# Altium schematic sheet PAGE2-POWER SUPPLY.SchDoc
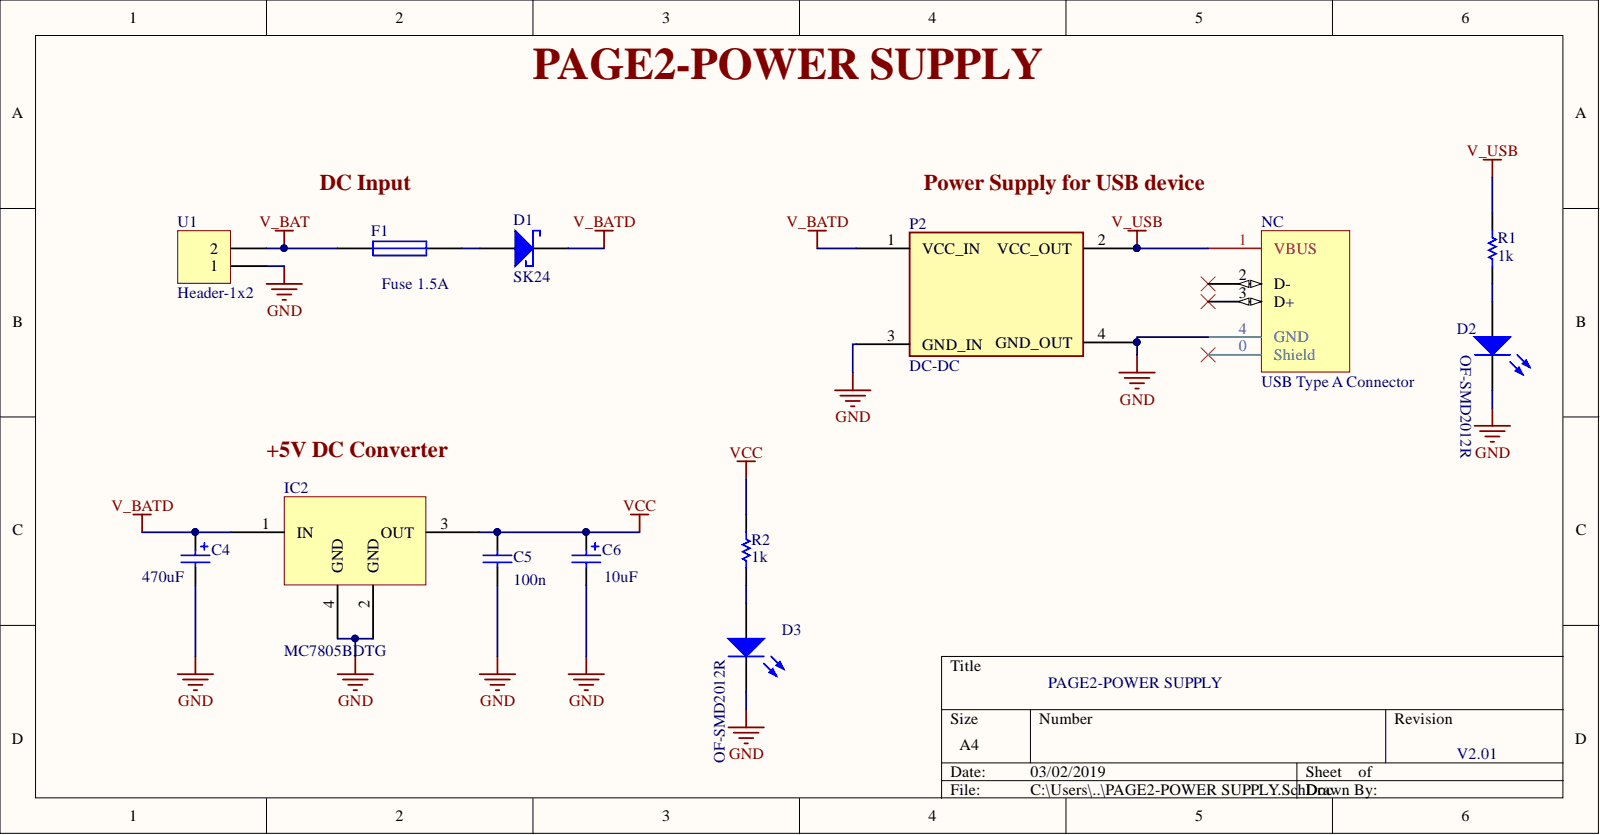
[diagram p0: PAGE2-POWER SUPPLY.SchDoc]

## Parts
C4  470uF  [Cap SMD 8x10]
C5  100n  [OF-SMD2012R]
C6  10uF  [Cap SMD 4x5.3]
D1  SK24  [DSO-C2/X3.3]
D2  OF-SMD2012R  [OF-SMD2012R]
D3  OF-SMD2012R  [OF-SMD2012R]
F1  Fuse 1.5A  [Fuse SMD 1812]
IC2  MC7805BDTG  [DPAK]
P2  DC-DC  [DC-DC LM2579 module]
R1  1k  [Res SMD 0805]
R2  1k  [Res SMD 0805]
U1  Implementation: Header-1x2  [CTB1000/2]  (hidden comment: CTB1000/2)
USB_Type_A_Connector  Implementation: NC  [USB, 2.0 TYPE A, PLUG, CABLE]  (hidden comment: USB Type A RA TH)

## Nets
GND: C4.2 C5.1 C6.2 D2.2 D3.2 IC2.2(GND) IC2.4(GND) P2.3(GND_IN) P2.4(GND_OUT) U1.1 USB_Type_A_Connector.4(GND)
V_BAT: F1.1 U1.2
V_BATD: C4.1 D1.2 IC2.1(IN) P2.1(VCC_IN)
V_USB: P2.2(VCC_OUT) R1.1 USB_Type_A_Connector.1(VBUS)
VCC: C5.2 C6.1 IC2.3(OUT) R2.1
NetD1_1: D1.1 F1.2
NetD2_1: D2.1 R1.2
NetD3_1: D3.1 R2.2

Not connected: USB_Type_A_Connector 0(Shield) 2(D-) 3(D+)

## Library data of the parts
C4 = Cap 470uF 16V: Capacitor: electrolytic; SMD; 470uF; 16V; Ø8x10mm; ±20%; 1000h; Implementation: Yes; Manifacturer: NICHICON; Manifacturer code: UWT1C471MNL1GS; Component Kind: Standard; Datasheet: http://www.tme.eu/en/Document/13afb0f2c55ee74aff11a994adc13fe6/e-wt.pdf; Distributor: TME.eu; Library Name: CapElectrolyticSMDLib_20150530.IntLib; Ordering code: UWT1C471MNL1GS; Value: 470uF
C5 = Capacitor: Manufacture: YAGEO; Manufacture code: CC0805KRX7R9BB104; Implementation: Yes; Oredering code: CC0805KRX7R9104; Component Kind: Standard; Datasheet: http://www.tme.eu/hr/Document/b4dafe2be75e8e02f2f5bf31efbc9903/cc_x7r_yageo.pdf; Description: Capacitor; Distributor: TME.eu; Library Name: Capacitors_20150530.IntLib; Value: 100n
C6 = Cap 10uF 16V: Capacitor: electrolytic; SMD; 10uF; 16V; Ø4x5.3mm; ±20%;; Implementation: Yes; Manifacturer: SAMWHA; Manifacturer code: RC1C106M04005VR; Component Kind: Standard; Datasheet: http://www.tme.eu/hr/Document/9ebdfef28f3efa32e383ad694a4e1e7a/RC_samwha.pdf; Distributor: TME.eu; Library Name: CapElectrolyticLib_20150530.IntLib; Ordering code: RC1C106M04005VR; Value: 10uF
D1 = SK24: Surface Mount Schottky Rectifier Diodes; Manufacture: DIOTEC SEMICONDUCTOR; Distibutor: TME.eu; Implementation: Yes; Ordering code: SK24-DIO; Value: DIOTEC SEMICONDUCTOR SK24; Component Kind: Standard; Datasheet: http://www.tme.eu/hr/Document/d871a179795794e96dbabe1c032e5183/SK22-DIO.pdf; Library Name: diode_schottky_rectifying.IntLib
D2 D3 = OF-SMD2012R: SMD 0805 LED SERIES; Manufacture: OPTOFLASH; Implementation: Yes; Value: OPTOFLASH OF-SMD2012R; Component Kind: Standard; Datasheet: http://www.tme.eu/hr/Document/008ce937b6e3e29f36c804db8273e7f6/of-smd2012r.pdf; Distributor: TME.eu; Library Name: LED_20150530.IntLib; Manufacture code: OF-SMD2012R; Ordering code: OF-SMD2012R
F1 = LITTELFUSE 1812L150/24MR: Fuse: PTC polymer; 1.5A; 1812L; Implementation: Yes; Component Kind: Standard; Datasheet: http://www.tme.eu/en/Document/71c32c9c3cb7bbfe5a74435d883a7bd8/8701984.pdf; Distributor: TME.eu; Library Name: FuseLib_20150530.IntLib; Manifacturer: LITTELFUSE; Manifacturer code: 1812L150/24MR; Ordering code: 1812L150/24MR; Value: Fuse 1.5A
IC2 = MC7805BDTG: Voltage stabiliser; fixed; 5V; 1A; DPAK; SMD; Package: tube; Implemaentation: YES; Manifacturer: ON SEMICONDUCTOR; Ordering code: 1652336; Component Kind: Standard; Datasheet: http://www.farnell.com/datasheets/1726421.pdf; Distributor: Farnell; Library Name: Linear_power_regulator_20150530.IntLib; Manifacturer code: MC7805BDTG; Value: MC7805BDTG
P2 = DC-DC LM2596 step down: Step down pretvarac napona DC-DC LM2596 konvertor ,; Component Kind: Standard; Library Name: modulesSCHLib.SchLib
R1 R2 = Res 1k: Resistor: thick film; SMD; 0805; 1kO; 0.125W; ±5%; -55÷125°C; Implementation: Yes; Manifacturer: ROYAL OHM; Manifacturer code: 0805S8J0102T5E; Ordering code: SMD0805-1K; Component Kind: Standard; Datasheet: http://www.tme.eu/en/Document/cd08025e8728292bb79d3f4577300b82/rezystor-smd.pdf; Distributor: TME.eu; Library Name: ResistorsLib_20150530.IntLib; Value: 1k
U1 = CTB1000/2: CTB1000/2, PCB TERMINAL BLOCK, 5MM, 2WAY; Manufacturer: CAMDENBOSS; Ordering code: CN19745; Value: clamp  2pin; Component Kind: Standard; Datasheet: http://www.farnell.com/datasheets/1870880.pdf; Distributor: Farnell; Library Name: clamp_2pin_2015030.IntLib; Manufacturer code: CTB1000/2
USB_Type_A_Connector = USB Type A RA TH: TE CONNECTIVITY / AMP  292303-1  USB, 2.0 TYPE A, PLUG, CABLE; Ordering code: 1076663; Component Kind: Standard; Datasheet: http://www.farnell.com/datasheets/1699414.pdf; Distributor: Farnell; Library Name: USB_Connector_Lib_20150530.IntLib; Manifacturer: TE CONNECTIVITY / AMP; Manifacturer code: 292303-1; Value: USB Type A RA TH
